# Altium schematic sheet dsPIC33AK.SchDoc(dsPIC33AK)
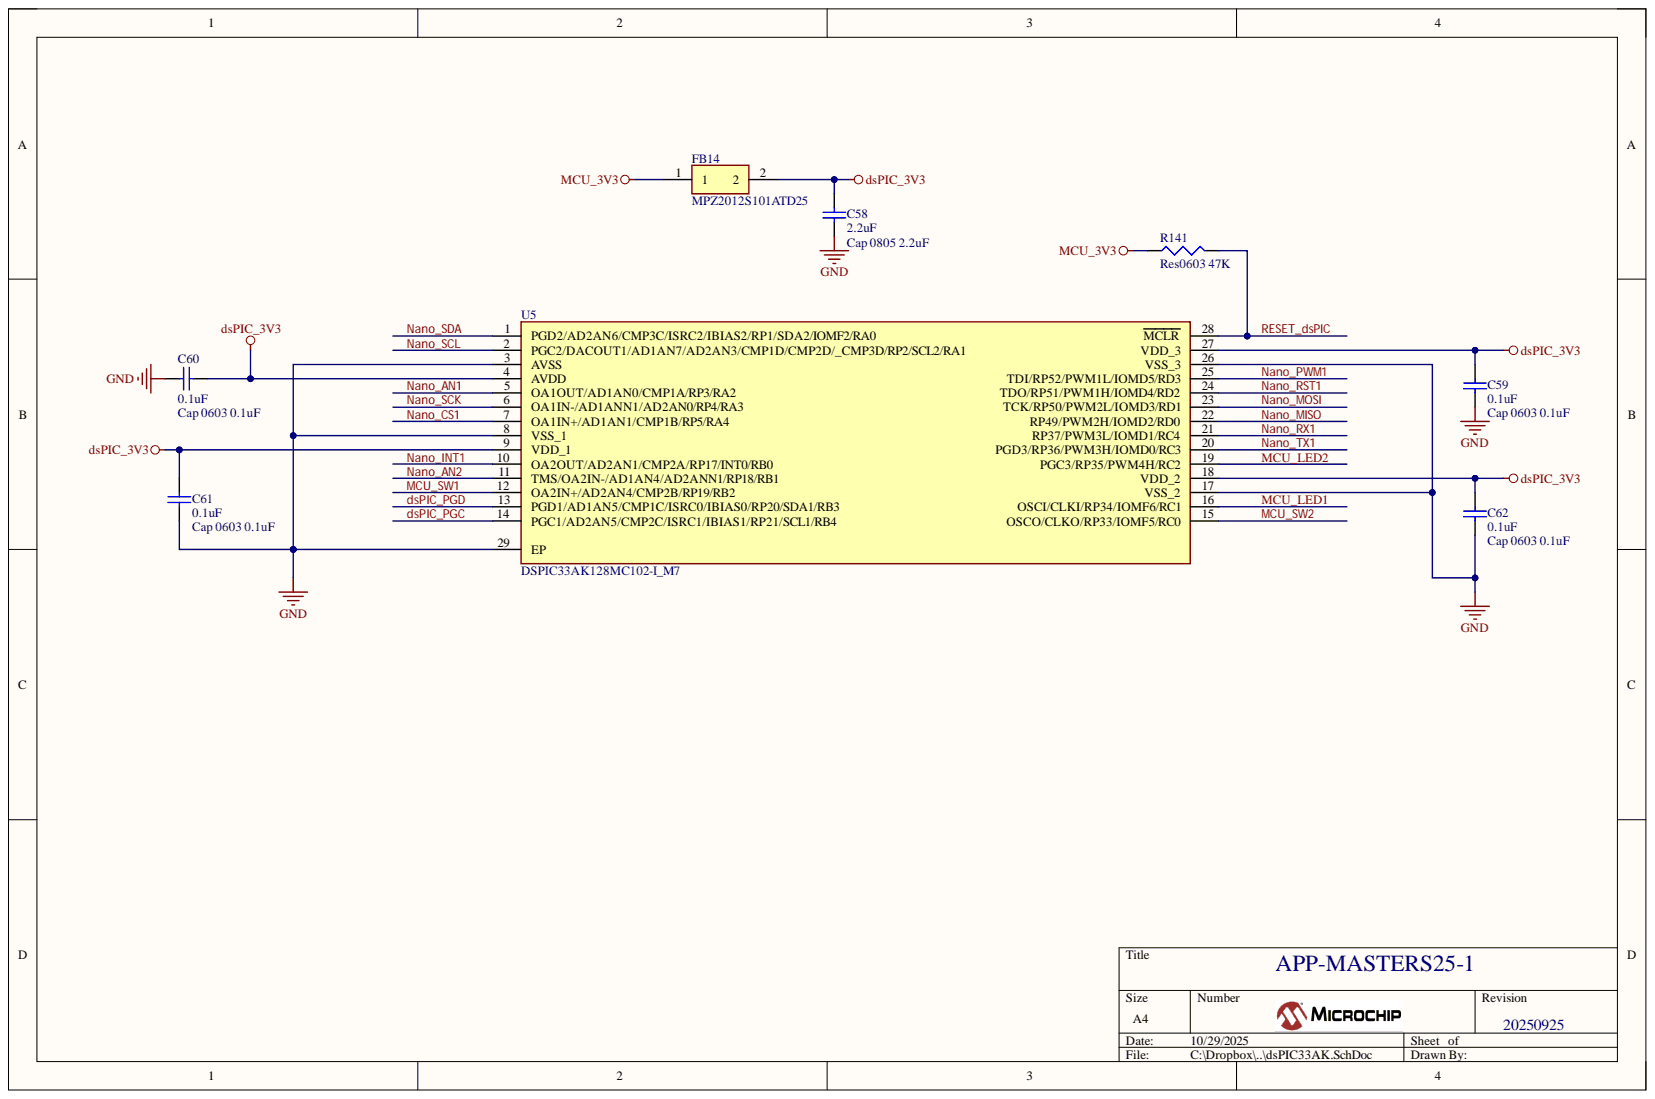
[diagram p0: dsPIC33AK.SchDoc]

## Parts
C58  Cap 0805 2.2uF  value 2.2uF  [C0805]
C59  Cap 0603 0.1uF  value 0.1uF  [0603C]
C60  Cap 0603 0.1uF  value 0.1uF  [0603C]
C61  Cap 0603 0.1uF  value 0.1uF  [0603C]
C62  Cap 0603 0.1uF  value 0.1uF  [0603C]
FB14  MPZ2012S101ATD25  [BEADC2012X105N]
R141  Res0603 47K  value 47K  [0603R]
U5  DSPIC33AK128MC102-I_M7  [QFN40P400X400X100-29N-D]

## Nets
dsPIC_3V3: C58.2 C59.2 C60.2 C61.2 C62.2 FB14.2 U5.4(AVDD) U5.9(VDD_1) U5.18(VDD_2) U5.27(VDD_3)
GND: C58.1 C59.1 C60.1 C61.1 C62.1 U5.3(AVSS) U5.8(VSS_1) U5.17(VSS_2) U5.26(VSS_3) U5.29(EP)
MCU_3V3: FB14.1 R141.1
dsPIC_PGC: U5.14(PGC1/AD2AN5/CMP2C/ISRC1/IBIAS1/RP21/SCL1/RB4)
dsPIC_PGD: U5.13(PGD1/AD1AN5/CMP1C/ISRC0/IBIAS0/RP20/SDA1/RB3)
MCU_LED1: U5.16(OSCI/CLKI/RP34/IOMF6/RC1)
MCU_LED2: U5.19(PGC3/RP35/PWM4H/RC2)
MCU_SW1: U5.12(OA2IN+/AD2AN4/CMP2B/RP19/RB2)
MCU_SW2: U5.15(OSCO/CLKO/RP33/IOMF5/RC0)
Nano_AN1: U5.5(OA1OUT/AD1AN0/CMP1A/RP3/RA2)
Nano_AN2: U5.11(TMS/OA2IN-/AD1AN4/AD2ANN1/RP18/RB1)
Nano_CS1: U5.7(OA1IN+/AD1AN1/CMP1B/RP5/RA4)
Nano_INT1: U5.10(OA2OUT/AD2AN1/CMP2A/RP17/INT0/RB0)
Nano_MISO: U5.22(RP49/PWM2H/IOMD2/RD0)
Nano_MOSI: U5.23(TCK/RP50/PWM2L/IOMD3/RD1)
Nano_PWM1: U5.25(TDI/RP52/PWM1L/IOMD5/RD3)
Nano_RST1: U5.24(TDO/RP51/PWM1H/IOMD4/RD2)
Nano_RX1: U5.21(RP37/PWM3L/IOMD1/RC4)
Nano_SCK: U5.6(OA1IN-/AD1ANN1/AD2AN0/RP4/RA3)
Nano_SCL: U5.2(PGC2/DACOUT1/AD1AN7/AD2AN3/CMP1D/CMP2D/_CMP3D/RP2/SCL2/RA1)
Nano_SDA: U5.1(PGD2/AD2AN6/CMP3C/ISRC2/IBIAS2/RP1/SDA2/IOMF2/RA0)
Nano_TX1: U5.20(PGD3/RP36/PWM3H/IOMD0/RC3)
RESET_dsPIC: R141.2 U5.28(MCLR)

## Library data of the parts
C58 = Cap 0805: Capacitor (Semiconductor SIM Model); Component Kind: Standard; LatestRevisionDate: 29-May-2009; LatestRevisionNote: IPC-7351 Footprint Added.; Library Name: MCHP_Parts_2016.SchLib; PackageDescription: Chip Capacitor; PackageReference: C1206; Published: 8-Jun-2000; Publisher: Altium Limited
C59-C62 = Cap 0603: Capacitor (Semiconductor SIM Model); Component Kind: Standard; LatestRevisionDate: 29-May-2009; LatestRevisionNote: IPC-7351 Footprint Added.; Library Name: MCHP_Parts_2016.SchLib; PackageDescription: Chip Capacitor; PackageReference: C0603; Published: 8-Jun-2000; Publisher: Altium Limited
FB14 = MPZ2012S221AT000: Ferrite Bead; Manufacturer_Name: TDK; Arrow Part Number: MPZ2012S221AT000; Arrow Price/Stock: https://www.arrow.com/en/products/mpz2012s221at000/tdk?region=nac; Component Kind: Standard; Datasheet Link: https://product.tdk.com/system/files/dam/doc/product/emc/emc/beads/catalog/beads_commercial_power_mpz2012_en.pdf; Height: 1.05mm; Library Name: SamacSys.SchLib; Manufacturer_Part_Number: MPZ2012S221AT000; Mouser Part Number: 810-MPZ2012S221AT000; Mouser Price/Stock: https://www.mouser.co.uk/ProductDetail/TDK/MPZ2012S221AT000?qs=pLY5GE0xrmJYuLs3YjLRbg%3D%3D
R141 = Res0603: Resistor; Component Kind: Standard; LatestRevisionDate: 29-May-2009; LatestRevisionNote: IPC-7351 Footprint Added.; Library Name: MCHP_Parts_2016.SchLib; PackageDescription: Chip Resistor; PackageReference: J1-0603; Published: 8-Jun-2000; Publisher: Altium Limited
U5 = DSPIC33AK128MC102-I_M7: Integrated Circuit; Manufacturer_Name: Microchip; Manufacturer_Part_Number: DSPIC33AK128MC102-I/M7; Component Kind: Standard; Datasheet Link: https://ww1.microchip.com/downloads/aemDocuments/documents/MCU16/ProductDocuments/DataSheets/dsPIC33AK128MC106-Family-Data-Sheet-DS70005539.pdf; Height: 1mm; Library Name: SamacSys.SchLib
